# Altium schematic sheet [11] SD.SchDoc("[11] SD")
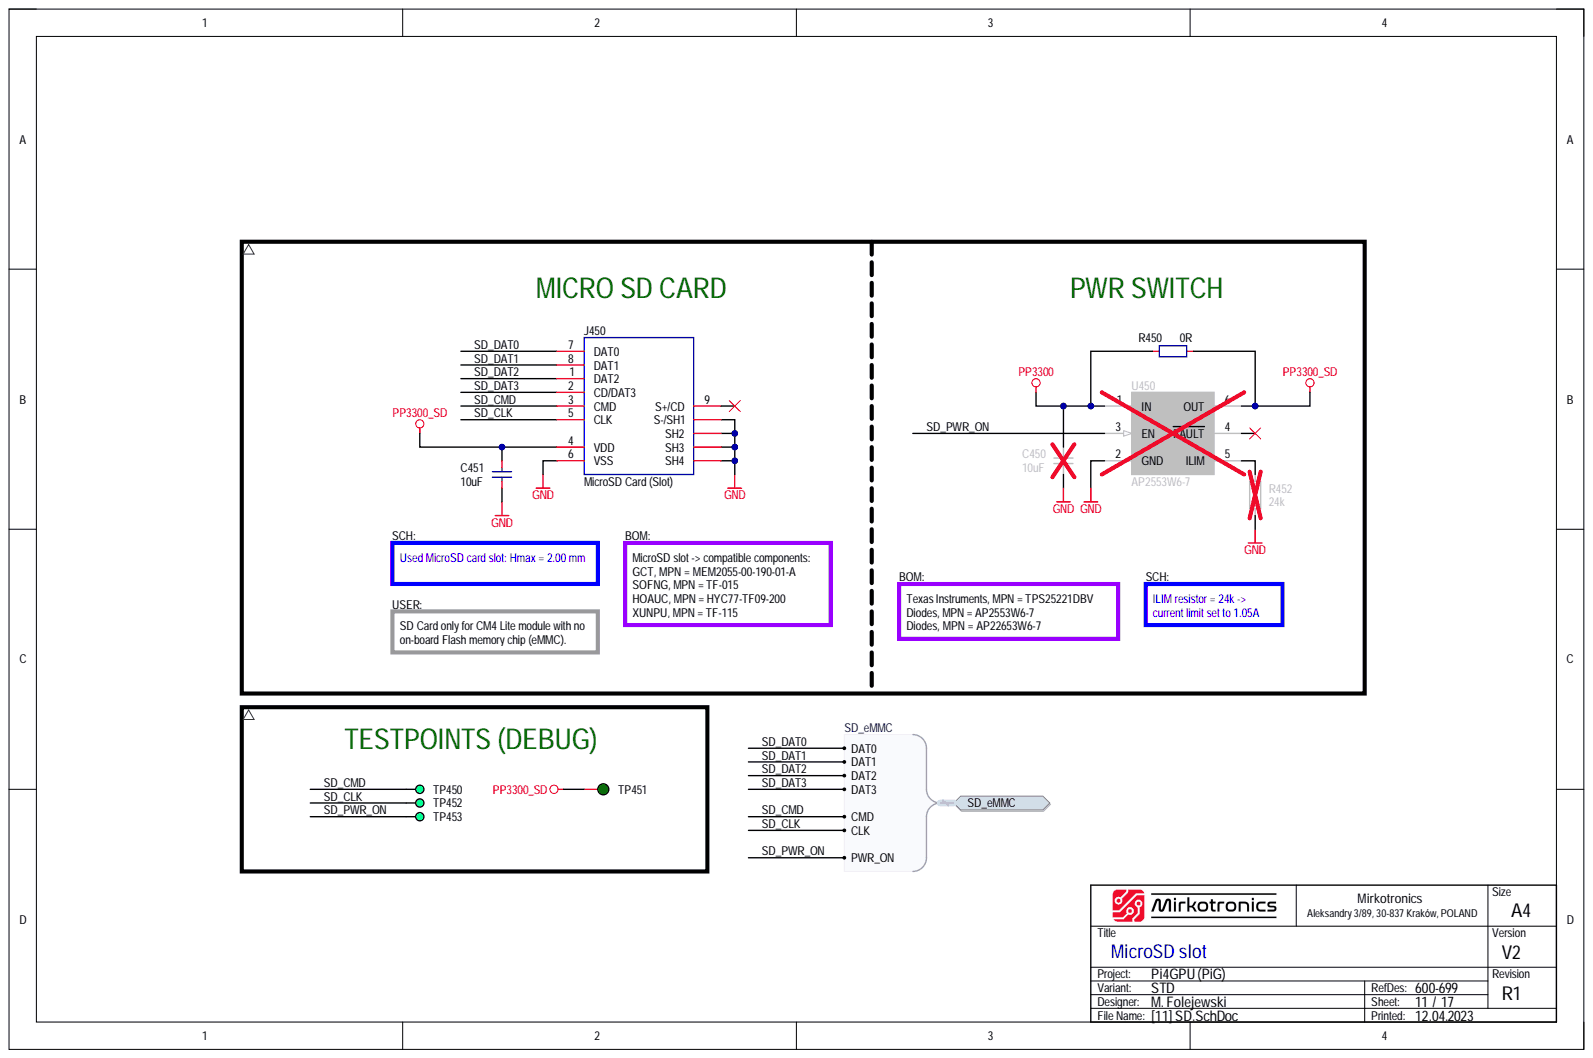
[diagram p0: [11] SD.SchDoc]

## Parts
C450  10uF  [CAP_0603_R]
C451  10uF  [CAP_0603_R]
J450  MicroSD Card (Slot)  Component Subtype: MicroSD Card  [CON_GCT_MEM2055_R]
R450  0R  [RES_0603_R]
R452  24k  [RES_0603_R]
TP450  DEBUG  [PCB_TP_DBG_50]  (value not drawn)  (no BOM)
TP451  ICT  [PCB_TP_ICT_100]  (value not drawn)  (no BOM)
TP452  DEBUG  [PCB_TP_DBG_50]  (value not drawn)  (no BOM)
TP453  DEBUG  [PCB_TP_DBG_50]  (value not drawn)  (no BOM)
U450  AP2553W6-7  [PKG_SOT23-6]

## Ports
SD_eMMC, SD_eMMC.CLK, SD_eMMC.CMD, SD_eMMC.DAT0, SD_eMMC.DAT1, SD_eMMC.DAT2, SD_eMMC.DAT3, SD_eMMC.PWR_ON

## Nets
GND: C450.1 C451.1 J450.6(VSS) J450.SH1(S-/SH1) J450.SH2 J450.SH3 J450.SH4 R452.1 U450.2(GND)
PP3300: C450.2 R450.2 U450.1(IN)
PP3300_SD: C451.2 J450.4(VDD) R450.1 TP451.1 U450.6(OUT)
SD_CLK: J450.5(CLK) TP452.1 ; port SD_eMMC
SD_CMD: J450.3(CMD) TP450.1 ; port SD_eMMC
SD_DAT0: J450.7(DAT0) ; port SD_eMMC
SD_DAT1: J450.8(DAT1) ; port SD_eMMC
SD_DAT2: J450.1(DAT2) ; port SD_eMMC
SD_DAT3: J450.2(CD/DAT3) ; port SD_eMMC
SD_PWR_ON: TP453.1 U450.3(EN) ; port SD_eMMC
NetR452_2: R452.2 U450.5(ILIM)

Not connected: J450 9(S+/CD); U450 4(FAULT)

## Library data of the parts
C450 C451 = CAP-0023: Capacitor; MLCC; GENERIC; TBD; TBD; TBD; TBD; SMD; 0603 (1608);; Database Table Name: CAPACITOR$; Component Kind: Standard; Component Subtype: MLCC; Component Type: Capacitor; Manufacturer: GENERIC; Mounting Type: SMD; MPN: GENERIC; Package (Case): 0603 (1608); Supplier: ?; Supplier 1: LCSC; Supplier Part Number 1: C19702; Value: 10uF; Library Name: MirFol_db_local.DbLib
J450 = CON_GCT_MEM2055: Connector; MicroSD Card Connector;; Manufacturer: TF-015; Package (Case): SMD; Component Kind: Standard; Component Type: Card Socket; Mounting Type: SMD; MPN: TF-015; Supplier: ?; Supplier 1: LCSC; Supplier Part Number 1: C113206; Value: MicroSD Card (Slot); Library Name: MirFol_lib.SchLib
R450 R452 = RES-0054: Resistor; Thick Film; GENERIC; TBD; TBD; TBD; TBD; TBD; SMD; 0603 (1608);; Database Table Name: RESISTOR$; Component Kind: Standard; Component Subtype: Thick Film; Component Type: Resistor; Manufacturer: GENERIC; Mounting Type: SMD; MPN: GENERIC; Package (Case): 0603 (1608); Supplier: ?; Supplier 1: LCSC; Library Name: MirFol_db_local.DbLib
  R450: Value: 0R
  R452: Supplier Part Number 1: C23352; Value: 24k
TP450 TP452 TP453 = PCB_TP_DBG: PCB Marking; Test Point (Debug);; Component Subtype: -; Component Type: Testpoint; Mounting Type: -; Supplier: ?; Value: DEBUG; Library Name: MirFol_lib.SchLib
TP451 = PCB_TP_ICT: Test Point (ICT); Specification: Testpoint (for ICT), SMD pad; Component Type: Testpoint (for ICT); Manufacturer: -; Mounting Type: Non component; MPN: -; Value: ICT; Library Name: MirFol_lib.SchLib
U450 = ICC_TXI_TPS25221_DBV: IC; Current Limited Switch;; Manufacturer: Diodes Incorporated; Package (Case): SOT26; Component Kind: Standard; Component Subtype: Current Limited Switch; Component Type: IC; Mounting Type: SMD; MPN: AP2553W6-7; Supplier: ?; Supplier 1: LCSC; Supplier Part Number 1: C212302; Value: AP2553W6-7; Library Name: MirFol_lib.SchLib
